# Altium schematic sheet LCD.SchDoc
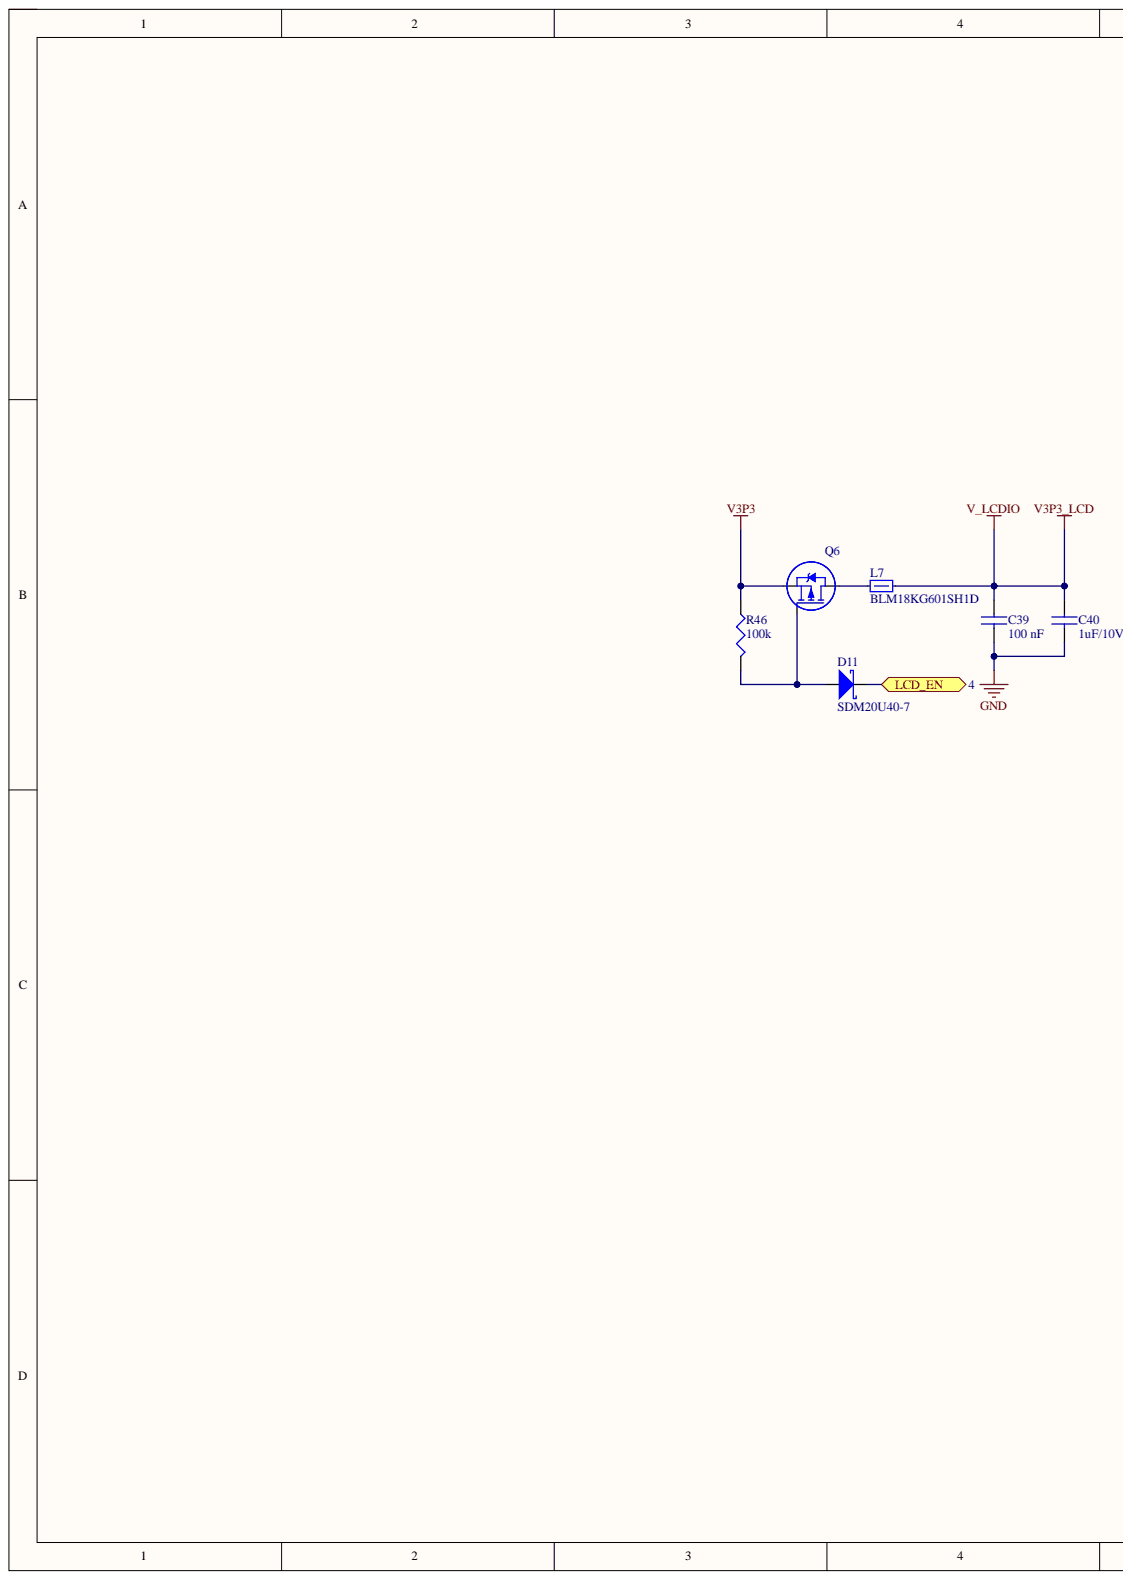
[diagram p0: LCD.SchDoc, left]
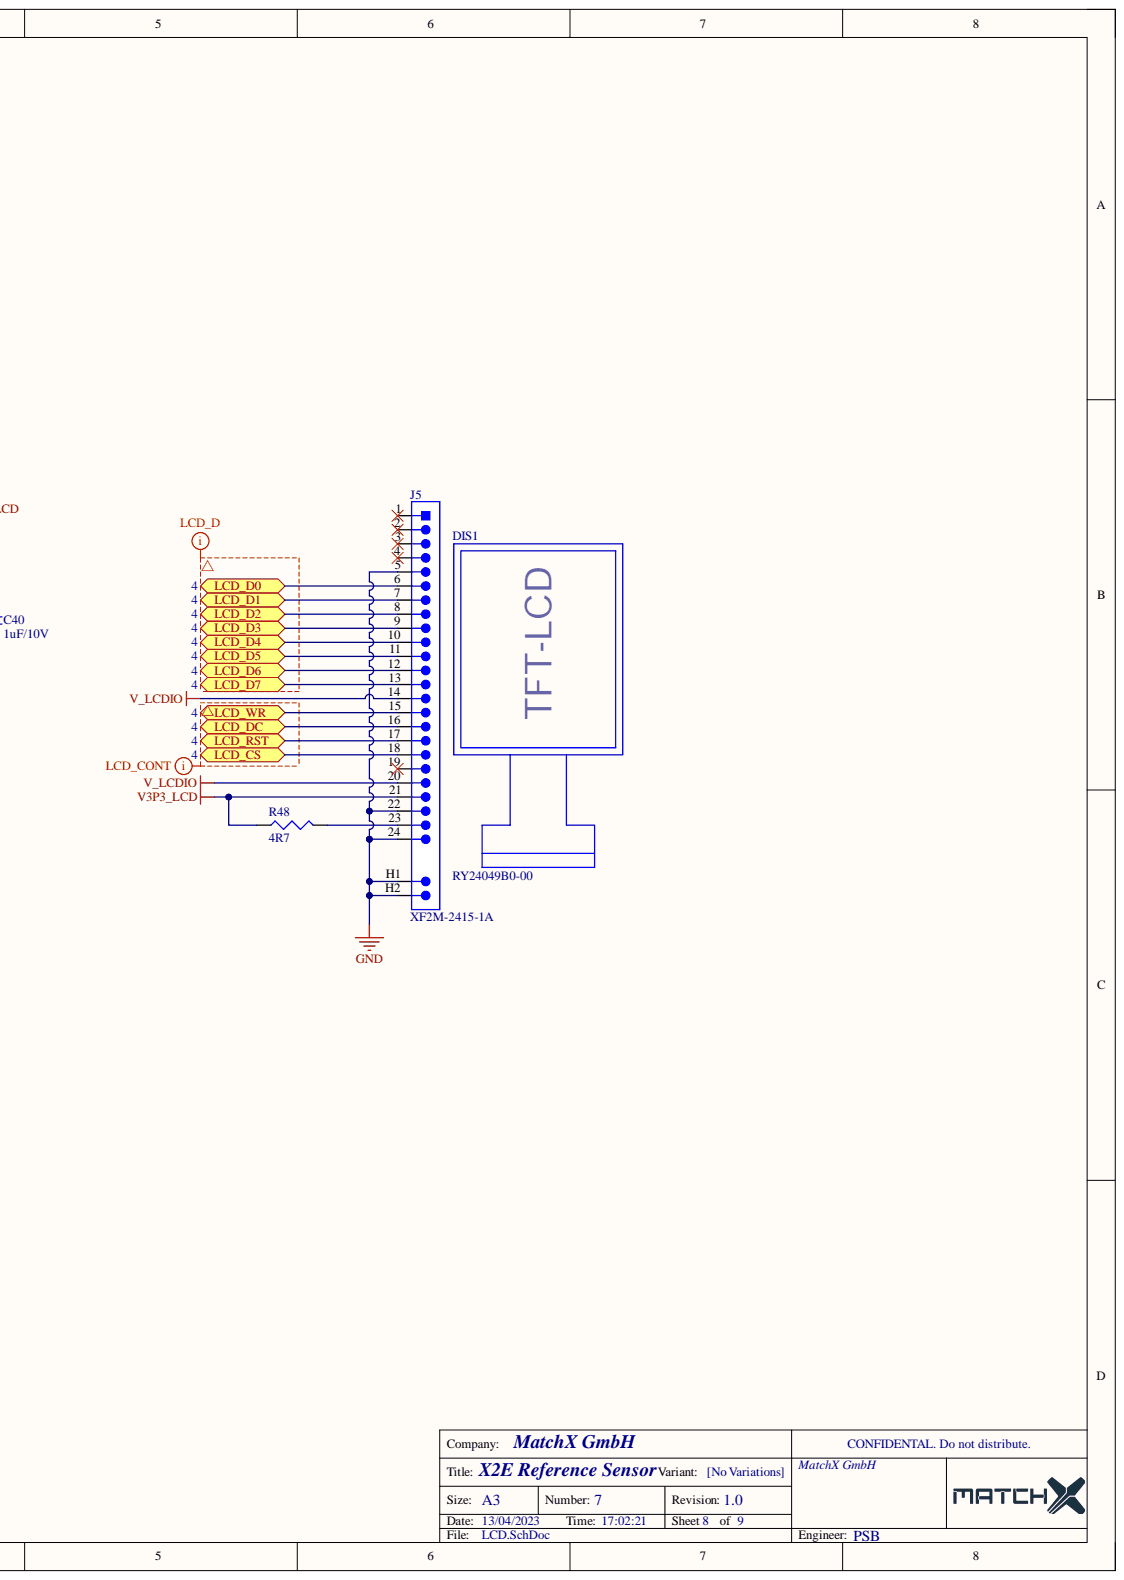
[diagram p1: LCD.SchDoc, right]

## Parts
C39  100 nF  [C0402]
C40  1uF/10V  [C0402]
D11  SDM20U40-7  [SOD-523]
DIS1  RY24049B0-00
J5  XF2M-2415-1A  [CON_FPC_XF2M-2415-1A]
L7  BLM18KG601SH1D  [L0603]
Q6  IRLML6401TRPBF  [SOT-23]  (value not drawn)
R46  100k  [R0603]
R48  4R7  [R0603]

## Ports
LCD_CS, LCD_D0, LCD_D1, LCD_D2, LCD_D3, LCD_D4, LCD_D5, LCD_D6, LCD_D7, LCD_DC, LCD_EN, LCD_RST, LCD_WR

## Nets
GND: C39.2 C40.2 J5.5 J5.22 J5.24 J5.H1 J5.H2
V3P3: Q6.2 R46.1
V3P3_LCD: C39.1 C40.1 J5.14 J5.20 J5.21 L7.2 R48.1
LCD_CS: J5.18 ; port LCD_CS
LCD_D0: J5.6 ; port LCD_D0
LCD_D1: J5.7 ; port LCD_D1
LCD_D2: J5.8 ; port LCD_D2
LCD_D3: J5.9 ; port LCD_D3
LCD_D4: J5.10 ; port LCD_D4
LCD_D5: J5.11 ; port LCD_D5
LCD_D6: J5.12 ; port LCD_D6
LCD_D7: J5.13 ; port LCD_D7
LCD_DC: J5.16 ; port LCD_DC
LCD_EN: D11.1 ; port LCD_EN
LCD_RST: J5.17 ; port LCD_RST
LCD_WR: J5.15 ; port LCD_WR
NetD11_2: D11.2 Q6.1 R46.2
NetJ5_23: J5.23 R48.2
NetL7_1: L7.1 Q6.3

Not connected: J5 1 2 3 4 19

## Library data of the parts
C39 = C0402-100nF: CAP, CERM, 100 nF, 16V, +/- 10%, X7R, 0402; CompType: Capacitor; Category: Capacitors; Component Kind: Standard; MountType: SMT; Operating Temperature: -55°C ~ 125°C; Package / Case: 0402; RoHS: RoHS Compliant; Technology: Ceramic; Temperature Coefficient: X7R; Tolerance: 10%; Value: 100 nF; Voltage - Rated: 16 V; Library Name: psblib.SCHLIB
C40 = C0402-1uF_10V: CAP, CERM, 1 uF, 10V, +/- 10%, X5R, 0402; CompType: Capacitor; Category: Capacitors; Component Kind: Standard; MountType: SMT; Operating Temperature: -55°C ~ 85°C; Package / Case: 0402; RoHS: RoHS Compliant; Technology: Ceramic; Temperature Coefficient: X5R; Tolerance: 10%; Value: 1uF/10V; Voltage - Rated: 10V; Library Name: psblib.SCHLIB
D11 = Diode_SDM20U40-7: Diode Schottky 40V 250mA (DC) Surface Mount SOD-523; Manufacturer: Diodes; Brand: Diodes Incorporated; Configuration: Single; If - Forward Current: 0.25 A; Ifsm - Forward Surge Current: 1 A; Ir - Reverse Current: 5 uA at 30 V; Pd - Power Dissipation: 150 mW; trr - Reverse Recovery time: 10 ns; Type: Schottky Barrier Diode; Unit Weight: 0.000035 oz; Vf - Forward Voltage: 0.6 V at 0.2 A; Component Kind: Standard; ComponentLink1Description: https://datasheet.ciiva.com/27052/1541976-27052427.pdf; ComponentLink1URL: https://datasheet.ciiva.com/27052/1541976-27052427.pdf; ComponentLink2Description: https://datasheet.ciiva.com/16199/ds30393-16199987.pdf; ComponentLink2URL: https://datasheet.ciiva.com/16199/ds30393-16199987.pdf; ComponentLink3Description: https://datasheet.ciiva.com/8303/ds30393-8303264.pdf; ComponentLink3URL: https://datasheet.ciiva.com/8303/ds30393-8303264.pdf; ComponentLink4Description: https://datasheet.ciiva.com/912/ds30393-912155.pdf; ComponentLink4URL: https://datasheet.ciiva.com/912/ds30393-912155.pdf; ComponentLink5Description: https://datasheet.ciiva.com/8301/ds30393-8301179.pdf; ComponentLink5URL: https://datasheet.ciiva.com/8301/ds30393-8301179.pdf; Factory Pack Quantity: 3000; Height: 0.65 mm; Length: 1.3 mm; Manufacturer Part Number: SDM20U40-7; Maximum Operating Temperature: + 125 C; Minimum Operating Temperature: - 65 C; Mounting Style: SMD/SMT; Operating Temperature Range: - 65 C to + 125 C; Package / Case: SOD-523; Packaging: Reel; Pricing 1: 1=0.37, 10=0.304, 100=0.1615, 500=0.1062, 1000=0.0722 (USD); Product: Schottky Diodes; Product Category: Schottky Diodes & Rectifiers; RoHS: True; Series: SDM20U40; Stock 1: 50745; Supplier 1: Digikey; Supplier Part Number 1: SDM20U40-7DIDKR-ND; Technology: Si; Termination Style: SMD/SMT; Vrrm - Repetitive Reverse Voltage: 40 V; Width: 0.9 mm; Library Name: psblib.SCHLIB
DIS1 = DISP_RY24049B0-00: TFT-LCD 2.4inch 240x320pix; Manufacturer: DONGGUAN RIYUE PHOTOELECTRIC CO.; Component Kind: Standard; Manufacturer Part Number: RY24049B0-00; Library Name: psblib.SCHLIB
J5 = CON_FPC_XF2M-2415-1A: CONN FPC 24POS 0.50MM R/A; Manufacturer: Omron; Actuator Material: Polymer; Contact Resistance: 50mR; Current Rating: 500mA; Gender: Female; Insulation Resistance: 100MR; Max Voltage Rating (AC): 50V; Max Voltage Rating (DC): 5.05kV; Number of Contacts: 24; Pitch: 0.5mm; Component Kind: Standard; ComponentLink1Description: https://datasheet.ciiva.com/30173/30en-xf2m-30173089.pdf?src-supplier=Onlinecomponents.com; ComponentLink1URL: https://datasheet.ciiva.com/30173/30en-xf2m-30173089.pdf?src-supplier=Onlinecomponents.com; ComponentLink2Description: https://datasheet.ciiva.com/11749/0900766b80fd28a0-11749813.pdf?src-supplier=RSComponents; ComponentLink2URL: https://datasheet.ciiva.com/11749/0900766b80fd28a0-11749813.pdf?src-supplier=RSComponents; ComponentLink3Description: https://datasheet.ciiva.com/669/en-xf2m-669462.pdf?src-supplier=Digi-Key; ComponentLink3URL: https://datasheet.ciiva.com/669/en-xf2m-669462.pdf?src-supplier=Digi-Key; ComponentLink4Description: https://datasheet.ciiva.com/669/en-xf2m-669463.pdf?src-supplier=Digi-Key; ComponentLink4URL: https://datasheet.ciiva.com/669/en-xf2m-669463.pdf?src-supplier=Digi-Key; ComponentLink5Description: https://datasheet.ciiva.com/669/en-xf2m-669464.pdf?src-supplier=Digi-Key; ComponentLink5URL: https://datasheet.ciiva.com/669/en-xf2m-669464.pdf?src-supplier=Digi-Key; ComponentLink6Description: https://datasheet.ciiva.com/5137/xf2m-0713-276160-5137176.pdf?src-supplier=Mouser; ComponentLink6URL: https://datasheet.ciiva.com/5137/xf2m-0713-276160-5137176.pdf?src-supplier=Mouser; ComponentLink7Description: https://datasheet.ciiva.com/7662/94858-7662440.pdf?src-supplier=Element14; ComponentLink7URL: https://datasheet.ciiva.com/7662/94858-7662440.pdf?src-supplier=Element14; ComponentLink8Description: https://datasheet.ciiva.com/7662/94858-7662441.pdf?src-supplier=Element14; ComponentLink8URL: https://datasheet.ciiva.com/7662/94858-7662441.pdf?src-supplier=Element14; ComponentLink9Description: https://datasheet.ciiva.com/16125/en-xf2m-16125242.pdf?src-supplier=Onlinecomponents.com; ComponentLink9URL: https://datasheet.ciiva.com/16125/en-xf2m-16125242.pdf?src-supplier=Onlinecomponents.com; ComponentLink10Description: https://datasheet.ciiva.com/22630/94858-22630556.pdf?src-supplier=Farnell; ComponentLink10URL: https://datasheet.ciiva.com/22630/94858-22630556.pdf?src-supplier=Farnell; ComponentLink11Description: https://datasheet.ciiva.com/3112/en-xf2m-3112575.pdf?src-supplier=Avnet; ComponentLink11URL: https://datasheet.ciiva.com/3112/en-xf2m-3112575.pdf?src-supplier=Avnet; Contact Material: Copper Alloy; Contact Plating: Gold; Depth: 6.2mm; Flammability Rating: UL94 V-0; Housing Color: Natural; Housing Material: Polymer; Lead Free: Lead Free; Lead Pitch: 0.5mm; Length: 16.1mm; Manufacturer Part Number: XF2M-2415-1A; Max Current Rating: 500mA; Max Operating Temperature: 85°C; Min Operating Temperature: -30°C; Mount: Surface Mount; Number of Poles: 24; Number of Positions: 24; Number of Rows: 1; Orientation: Right Angle; Package Quantity: 1; Packaging: Tape and Reel; Pricing 1: 1=1,86000, 10=1,69000, 25=1,58680, 50=1,51780, 100=1,44890, 250=1,31088, 500=1,20738 (USD); Radiation Hardening: No; REACH SVHC: No SVHC; RoHS: Yes; Stock 1: 14423; Supplier 1: Digi-Key; Supplier Part Number 1: OR721CT-ND; Termination: Solder; Voltage Rating: 50V; Voltage Rating (AC): 50V; Voltage Rating (DC): 50V; Library Name: psblib.SCHLIB
L7 = BLM18KG601SH1D: Ferrite Beads 0603 600ohms 1.3A DCR=.15ohms; Manufacturer: Murata Electronics North America; DC Resistance (DCR) (Max): 150 mOhm; Filter Type: Power Line; Impedance @ Frequency: 600 Ohm @ 100MHz; Number of Lines: 1; Category: Filters; Component Kind: Standard; ComponentLink1Description: http://www.murata.com/en-global/products/productdata/8796737273886/QNFA9101.pdf; ComponentLink1URL: http://www.murata.com/en-global/products/productdata/8796737273886/QNFA9101.pdf; Current Rating (Max): 1.3A; Height (Max): 0.037" (0.95mm); Manufacturer Part Number: BLM18KG601SH1D; Mounting Type: Surface Mount; Operating Temperature: -55°C ~ 125°C; Package / Case: 0603 (1608 Metric); Packaging: Cut Tape (CT); Pricing 1: 1=0.11, 10=0.088, 50=0.058, 100=0.0502, 250=0.0396, 500=0.03344, 1000=0.02816 (USD); Ratings: AEC-Q200; RoHS: RoHS Compliant; Size / Dimension: 0.063" L x 0.032" W (1.60mm x 0.80mm); Stock 1: 5830; Supplier 1: Digi-Key; Supplier Part Number 1: 490-13259-1-ND; Library Name: psblib.SCHLIB
Q6 = PMOSFET_ IRLML6401PbF: MOSFET P-CH 12V 4.3A SOT-23; Manufacturer: Infineon Technologies Americas Corp.; Current - Continuous Drain (Id) @ 25°C: 4.3A (Ta); FET Feature: Logic Level Gate, 1.8V Drive; FET Type: MOSFET P-Channel, Metal Oxide; Gate Charge (Qg) @ Vgs: 15nC @ 5V; Input Capacitance (Ciss) @ Vds: 830pF @ 10V; Power - Max: 1.3W; Rds On (Max) @ Id, Vgs: 50 mOhm @ 4.3A, 4.5V; Vgs(th) (Max) @ Id: 950mV @ 250µA; Category: Discrete Semiconductor Products; Component Kind: Standard; ComponentLink1Description: http://www.irf.com/product-info/datasheets/data/irlml6401pbf.pdf; ComponentLink1URL: http://www.irf.com/product-info/datasheets/data/irlml6401pbf.pdf; Drain to Source Voltage (Vdss): 12V; Manufacturer Part Number: IRLML6401TRPBF; Mounting Type: Surface Mount; Operating Temperature: -55°C ~ 150°C (TJ); Package / Case: TO-236-3, SC-59, SOT-23-3; Packaging: Tape & Reel (TR); Pricing 1: 3000=0.1254, 6000=0.1178, 15000=0.1102, 30000=0.10108, 75000=0.09728, 150000=0.09348 (USD); RoHS: RoHS Compliant; Stock 1: 171000; Supplier 1: Digi-Key; Supplier Device Package: Micro3™/SOT-23; Supplier Part Number 1: IRLML6401PBFTR-ND; Library Name: psblib.SCHLIB
R46 = R0603-100k: RES, 100k, 1%, 0.063 W, 0603; .Checked PCB: OK RF; .Checked SCH: RF; Power (Watts): 0.063W, 1/16W; Category: Resistors; Component Kind: Standard; Composition: Thick Film; Features: -; Height: 0.016" (0.40mm); Number of Terminations: 2; Package / Case: 0603 [1608 Metric]; RoHS: RoHS Compliant; Size / Dimension: 0.063" L x 0.031" W (1.60mm x 0.80mm); Tolerance: ±1%; Value: 100k; Library Name: psblib.SCHLIB
R48 = R0603-4R7: RES, 4R7 1%, 0.063 W, 0603; .Checked PCB: OK RF; .Checked SCH: RF; Power (Watts): 0.063W, 1/16W; Category: Resistors; Component Kind: Standard; Composition: Thick Film; Features: -; Height: 0.016" (0.40mm); Number of Terminations: 2; Package / Case: 0603 [1608 Metric]; RoHS: RoHS Compliant; Size / Dimension: 0.063" L x 0.031" W (1.60mm x 0.80mm); Tolerance: ±1%; Value: 4R7; Library Name: psblib.SCHLIB
